# Altium schematic sheet Sheet1.SchDoc
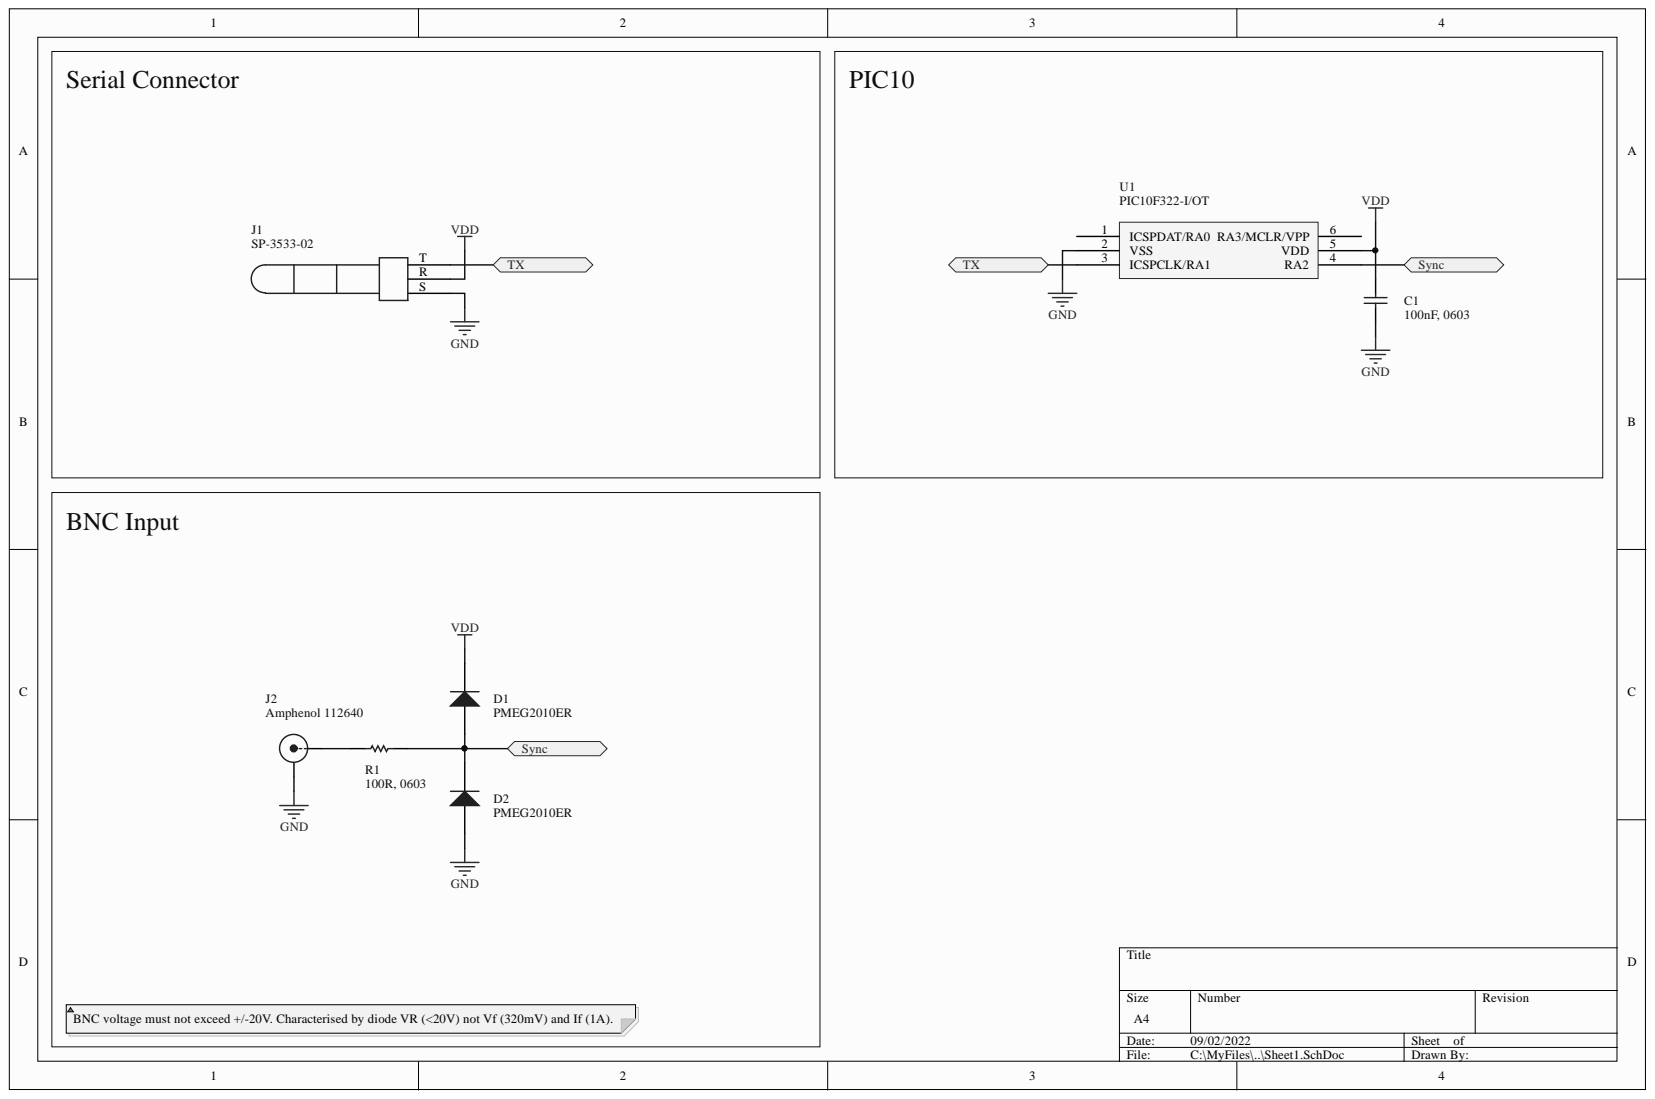
[diagram p0: Sheet1.SchDoc]

## Parts
C1  100nF, 0603  [Capacitor 0603]
D1  PMEG2010ER  [SOD-123]
D2  PMEG2010ER  [SOD-123]
J1  SP-3533-02  [SP-3533-02]
J2  Amphenol 112640  [Amphenol 112640]
R1  100R, 0603  [Resistor 0603]
U1  PIC10F322-I/OT  [SOT-23-6]  (value not drawn)

## Ports
SYNC, TX

## Nets
GND: C1.1 D2.A J1.S J2.Shield U1.2(VSS)
VDD: C1.2 D1.K J1.R U1.5(VDD)
TX: J1.T U1.3(ICSPCLK/RA1) ; port TX
NetD1_A: D1.A D2.K R1.2 U1.4(RA2) ; port SYNC
NetJ2_Signal: J2.Signal R1.1

Not connected: U1 1(ICSPDAT/RA0) 6(RA3/MCLR/VPP)

## Library data of the parts
C1 = Capacitor: Component Kind: Standard; Library Name: x-io.SchLib
D1 D2 = Diode: Component Kind: Standard; Library Name: x-io.SchLib
J2 = BNC: Component Kind: Standard; Library Name: x-io.SchLib
R1 = Resistor: Component Kind: Standard; Library Name: x-io.SchLib
J1 = SP-3533-02: Component Kind: Standard; Library Name: x-io.SchLib
U1 = PIC10F322-I/OT: Component Kind: Standard; Library Name: x-io.SchLib
